# Altium schematic sheet HolidayTree_Schematic_LEDs.SchDoc(HolidayTree_Schematic_LEDs)
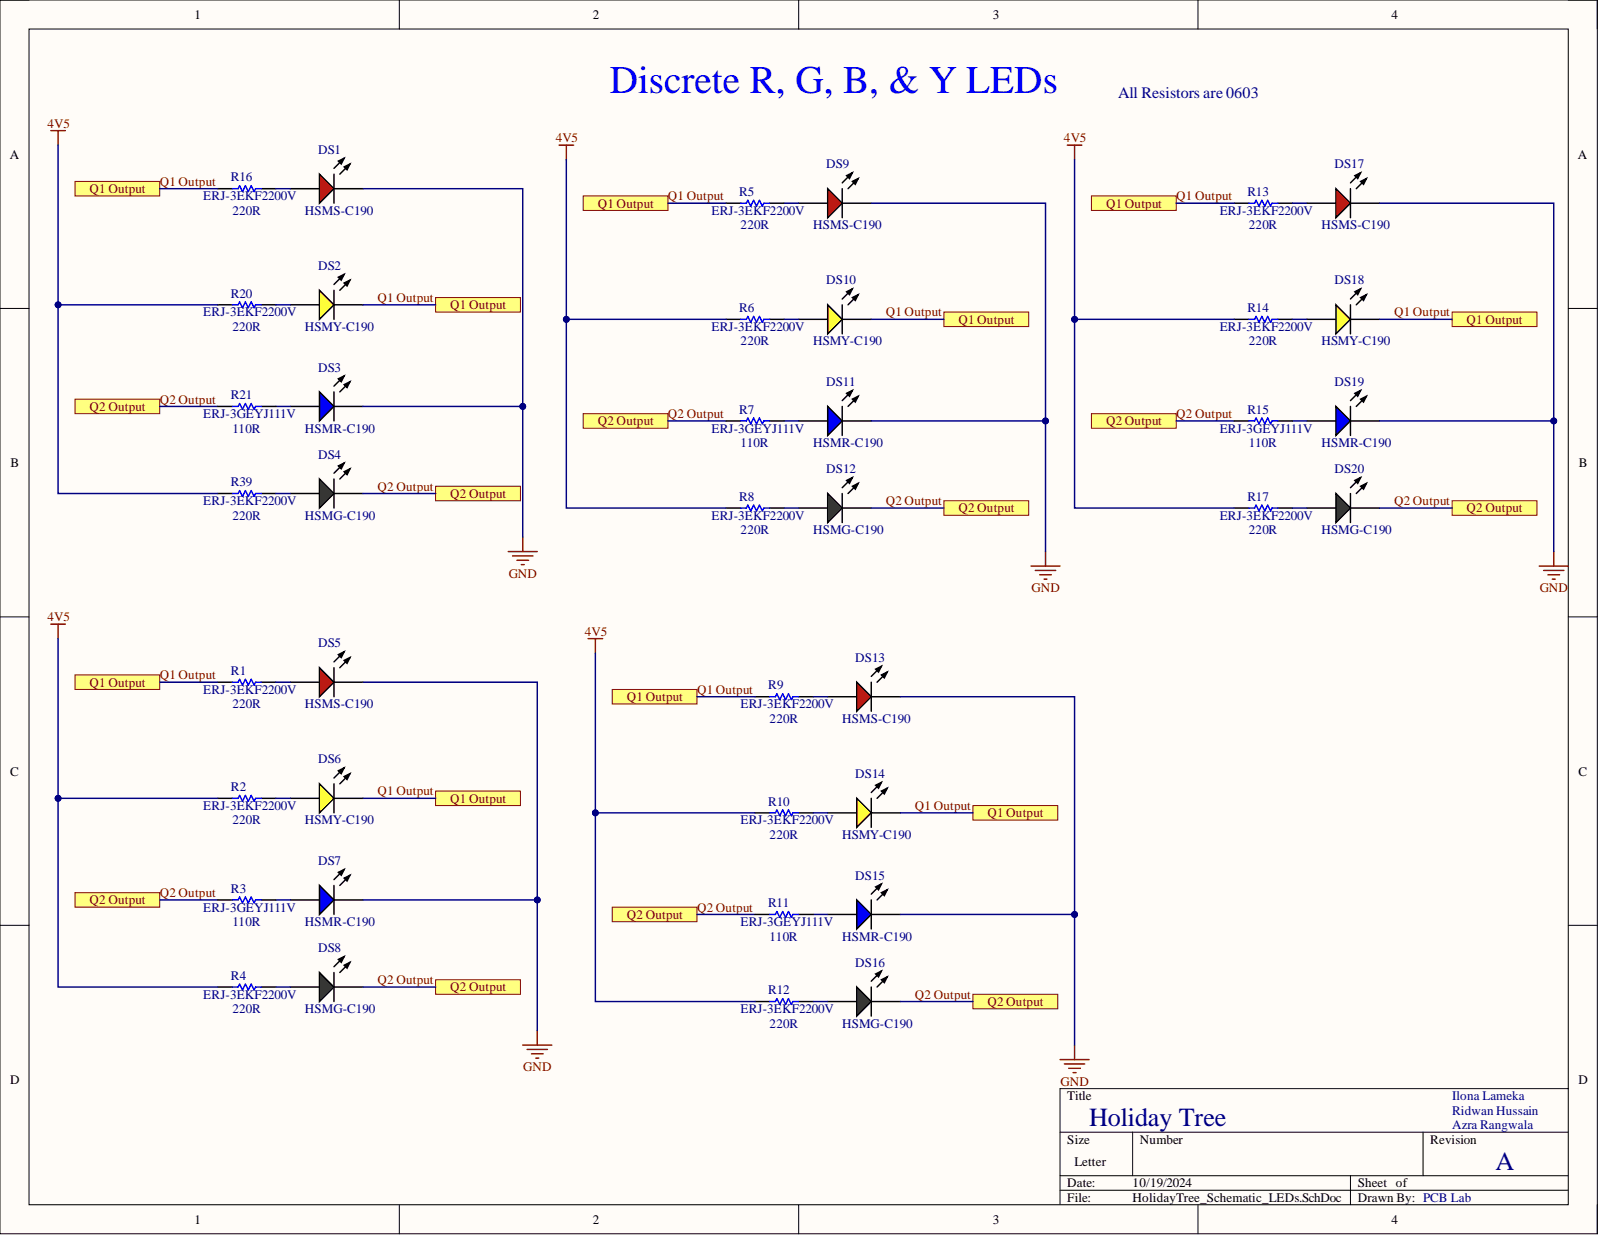
[diagram p0: HolidayTree_Schematic_LEDs.SchDoc]

## Parts
DS1  HSMS-C190
DS2  HSMY-C190
DS3  HSMR-C190
DS4  HSMG-C190
DS5  HSMS-C190
DS6  HSMY-C190
DS7  HSMR-C190
DS8  HSMG-C190
DS9  HSMS-C190
DS10  HSMY-C190
DS11  HSMR-C190
DS12  HSMG-C190
DS13  HSMS-C190
DS14  HSMY-C190
DS15  HSMR-C190
DS16  HSMG-C190
DS17  HSMS-C190
DS18  HSMY-C190
DS19  HSMR-C190
DS20  HSMG-C190
R1  ERJ-3EKF2200V
R2  ERJ-3EKF2200V
R3  ERJ-3GEYJ111V
R4  ERJ-3EKF2200V
R5  ERJ-3EKF2200V
R6  ERJ-3EKF2200V
R7  ERJ-3GEYJ111V
R8  ERJ-3EKF2200V
R9  ERJ-3EKF2200V
R10  ERJ-3EKF2200V
R11  ERJ-3GEYJ111V
R12  ERJ-3EKF2200V
R13  ERJ-3EKF2200V
R14  ERJ-3EKF2200V
R15  ERJ-3GEYJ111V
R16  ERJ-3EKF2200V
R17  ERJ-3EKF2200V
R20  ERJ-3EKF2200V
R21  ERJ-3GEYJ111V
R39  ERJ-3EKF2200V

## Ports
Q1 Output, Q2 Output

## Nets
4V5: R2.1 R4.1 R6.1 R8.1 R10.1 R12.1 R14.1 R17.1 R20.1 R39.1
GND: DS1.2 DS3.2 DS5.2 DS7.2 DS9.2 DS11.2 DS13.2 DS15.2 DS17.2 DS19.2
Q1 Output: DS2.2 DS6.2 DS10.2 DS14.2 DS18.2 R1.1 R5.1 R9.1 R13.1 R16.1 ; port Q1 Output
Q2 Output: DS4.2 DS8.2 DS12.2 DS16.2 DS20.2 R3.1 R7.1 R11.1 R15.1 R21.1 ; port Q2 Output
NetDS1_1: DS1.1 R16.2
NetDS2_1: DS2.1 R20.2
NetDS3_1: DS3.1 R21.2
NetDS4_1: DS4.1 R39.2
NetDS5_1: DS5.1 R1.2
NetDS6_1: DS6.1 R2.2
NetDS7_1: DS7.1 R3.2
NetDS8_1: DS8.1 R4.2
NetDS9_1: DS9.1 R5.2
NetDS10_1: DS10.1 R6.2
NetDS11_1: DS11.1 R7.2
NetDS12_1: DS12.1 R8.2
NetDS13_1: DS13.1 R9.2
NetDS14_1: DS14.1 R10.2
NetDS15_1: DS15.1 R11.2
NetDS16_1: DS16.1 R12.2
NetDS17_1: DS17.1 R13.2
NetDS18_1: DS18.1 R14.2
NetDS19_1: DS19.1 R15.2
NetDS20_1: DS20.1 R17.2

## Library data of the parts
DS1 DS5 DS9 DS13 DS17 = CMP-2000-06427-1: LED Uni-Color Red, 52 mW, 20 mA, -40 to 85 degC, 2-Pin SMD, RoHS, Tape and Reel; Manufacturer: Avago; Chip Material: GaP; DELETED: Compliant; Dominant Wave Length: 626nm; Forward Current: 25mA; Forward Voltage: 2.6V; High Brightness: No; Lens Type: ColorlessDiffused; Lum Intensity: 10mcd; Main Category: ChipLED; Number of  Elements: 1; Peak Wavelength: 630nm; Power Dissipation: 52W; Product Depth (mm): 0.8mm; Product Length (mm): 1.6mm; Reverse Voltage: 5V; Shape Type: Rectangular; Test Current (It): 20mA; Viewing Angle: 170deg; Component Kind: Standard; Datasheet URL: http://docs.avagotech.com/docs/AV02-0551EN; Datasheet Version: 03/2012; Emitting Color: Red; Lens Dimensions: 1x0.8x0.5mm; Library Name: Altium Content Vault; Luminous Intensity: 10mcd; Manufacturer URL: http://www.avagotech.com/; Mounting: SurfaceMount; Mounting Technology: SurfaceMount; Operating Temp Range: -40Cto85C; Operating Temperature Classification: Industrial; Package Description: 2-Pin Surface Mount Device, Body 1.6 x 0.8 mm, Height 0.9 mm; Package Reference: HSMX-C190; Package Type: ChipLed; Packaging: TapeandReel; Pin Count: 2; Product Height (mm): 0.8mm; Rad Hardened: No; Type: Uni-Color
DS2 DS6 DS10 DS14 DS18 = CMP-1744-00003-1: LED Uni-Color Yellow, 65 mW, 20 mA, -40 to 85 degC, 2-Pin SMD, RoHS, Tape and Reel; Case\Package: 0603; Power Rating: 100 mW; Resistance: 390 Ohm; Tolerance: 1%; Voltage Rating: 50 V; Case Code (Imperial): 0603; Case Code (Metric): 1608; Component Kind: Standard; ComponentLink1Description: Manufacturer URL; ComponentLink1URL: http://www.avagotech.com/; ComponentLink2Description: Datasheet; ComponentLink2URL: http://docs.avagotech.com/docs/AV02-0551EN; ComponentLink3Description: Package Specification; ComponentLink3URL: http://www.fairchildsemi.com/dwg/MA/MA03D.pdf; DatasheetVersion: Mar-12; Height: 0.45 mm; Length: 1.6 mm; Library Name: Altium Content Vault; Max Operating Temperature: 155 degC; Min Operating Temperature: -55 degC; Mount: Surface Mount; Package Quantity: 5000; PackageDescription: 2-Pin Surface Mount Device, Body 1.6 x 0.8 mm, Height 0.9 mm; PackageReference: HSMX-C190; Packaging: Tape and Reel; Pins: 2; REACH SVHC: No SVHC; RoHSCompliant: true; Voltage Rating (DC): 50 V; Width: 0.8 mm
DS3 DS7 DS11 DS15 DS19 = CMP-1744-00007-1: LED Uni-Color Blue, 78 mW, 20 mA, -40 to 85 degC, 2-Pin SMD, RoHS, Tape and Reel; Case\Package: TO-236-3; Elements: 1; Input Capacitance: 73 pF; Max Power Dissipation: 360 mW; Nominal Dual Supply Voltage: -50 V; Nominal Vgs: -1.7 V; Rds On Max: 10 Ohm; Typical Rise Time: 6.3 ns; Component Kind: Standard; ComponentLink1Description: Manufacturer URL; ComponentLink1URL: http://www.avagotech.com/; ComponentLink2Description: Datasheet; ComponentLink2URL: http://docs.avagotech.com/docs/AV02-0977EN; ComponentLink3Description: Package Specification; ComponentLink3URL: http://www.fairchildsemi.com/dwg/MA/MA03D.pdf; DatasheetVersion: Apr-10; Height: 0.93 mm; Length: 2.92 mm; Library Name: Altium Content Vault; Max Operating Temperature: 150 degC; Min Operating Temperature: -55 degC; Mount: Surface Mount; Package Quantity: 3000; PackageDescription: 2-Pin Surface Mount Device, Body 1.6 x 0.8 mm, Height 0.9 mm; PackageReference: HSMX-C190; Packaging: Tape and Reel; Pins: 3; Radiation Hardening: No; REACH SVHC: No SVHC; RoHSCompliant: true; Width: 1.3 mm
DS4 DS8 DS12 DS16 DS20 = CMP-1744-00002-1: Chip LED, Green, 2.2 V, -40 to 85 degC, 2-Pin SMD, RoHS, Tape and Reel; Access Time: 3500 ns; Case\Package: SOIC; Clock Frequency: 100 KHz; Density: 32 kb; Frequency: 400 KHz; Interface: Serial; Max Supply Voltage: 5.5 V; Min Supply Voltage: 1.7 V; Nominal Supply Current: 2 mA; Component Kind: Standard; ComponentLink1Description: Manufacturer URL; ComponentLink1URL: http://www.avagotech.com/; ComponentLink2Description: Datasheet; ComponentLink2URL: http://docs.avagotech.com/docs/AV02-0551EN; ComponentLink3Description: Package Specification; ComponentLink3URL: http://www.fairchildsemi.com/dwg/MA/MA03D.pdf; DatasheetVersion: Mar-12; Height: 1.5 mm; Length: 5 mm; Library Name: Altium Content Vault; Max Clock Frequency: 100 KHz; Max Operating Temperature: 85 degC; Min Operating Temperature: -40 degC; Mount: Surface Mount; Package Quantity: 3000; PackageDescription: 2-Pin Surface Mount Device, Body 1.6 x 0.8 mm, Height 0.9 mm; PackageReference: HSMX-C190; Packaging: Tape and Reel; Pins: 8; Radiation Hardening: No; REACH SVHC: No SVHC; RoHSCompliant: true; Width: 4 mm
R1 R2 R4-R6 R8-R10 R12-R14 R16 R17 R20 R39 = CMP-2000-00335-2: Chip Resistor, 220 Ohm, +/- 1%, 0.1 W, -55 to 155 degC, 0603 (1608 Metric), RoHS, Tape and Reel; Case\Package: 0603; Power Rating: 100 mW; Resistance: 22 Ohm; Voltage Rating: 50 V; Case Code (Imperial): 0603; Case Code (Metric): 1608; Component Kind: Standard; ComponentLink1Description: Manufacturer URL; ComponentLink1URL: http://www.panasonic.com/; ComponentLink2Description: Datasheet; ComponentLink2URL: http://industrial.panasonic.com/cdbs/www-data/pdf/RDA0000/AOA0000C86.pdf; DatasheetVersion: Apr-15; Height: 0.45 mm; Length: 1.6 mm; Library Name: Altium Content Vault; Max Operating Temperature: 155 degC; Min Operating Temperature: -55 degC; Mount: Surface Mount; Package Quantity: 5000; PackageDescription: 2-Pin Surface Mount Device, Body 1.6 x 0.85 mm; PackageReference: 0603; Packaging: Tape and Reel; Pins: 2; REACH SVHC: No SVHC; RoHSCompliant: true; Tolerance: 1%; Voltage Rating (DC): 50 V; Width: 0.8 mm
R3 R7 R11 R15 R21 = CMP-2000-00708-1: Manufacturer: Panasonic; Component Kind: Standard; Library Name: Altium Content Vault; Part Number: ERJ-3GEYJ111V
